# Altium schematic sheet 02-ece353_carrier.SchDoc
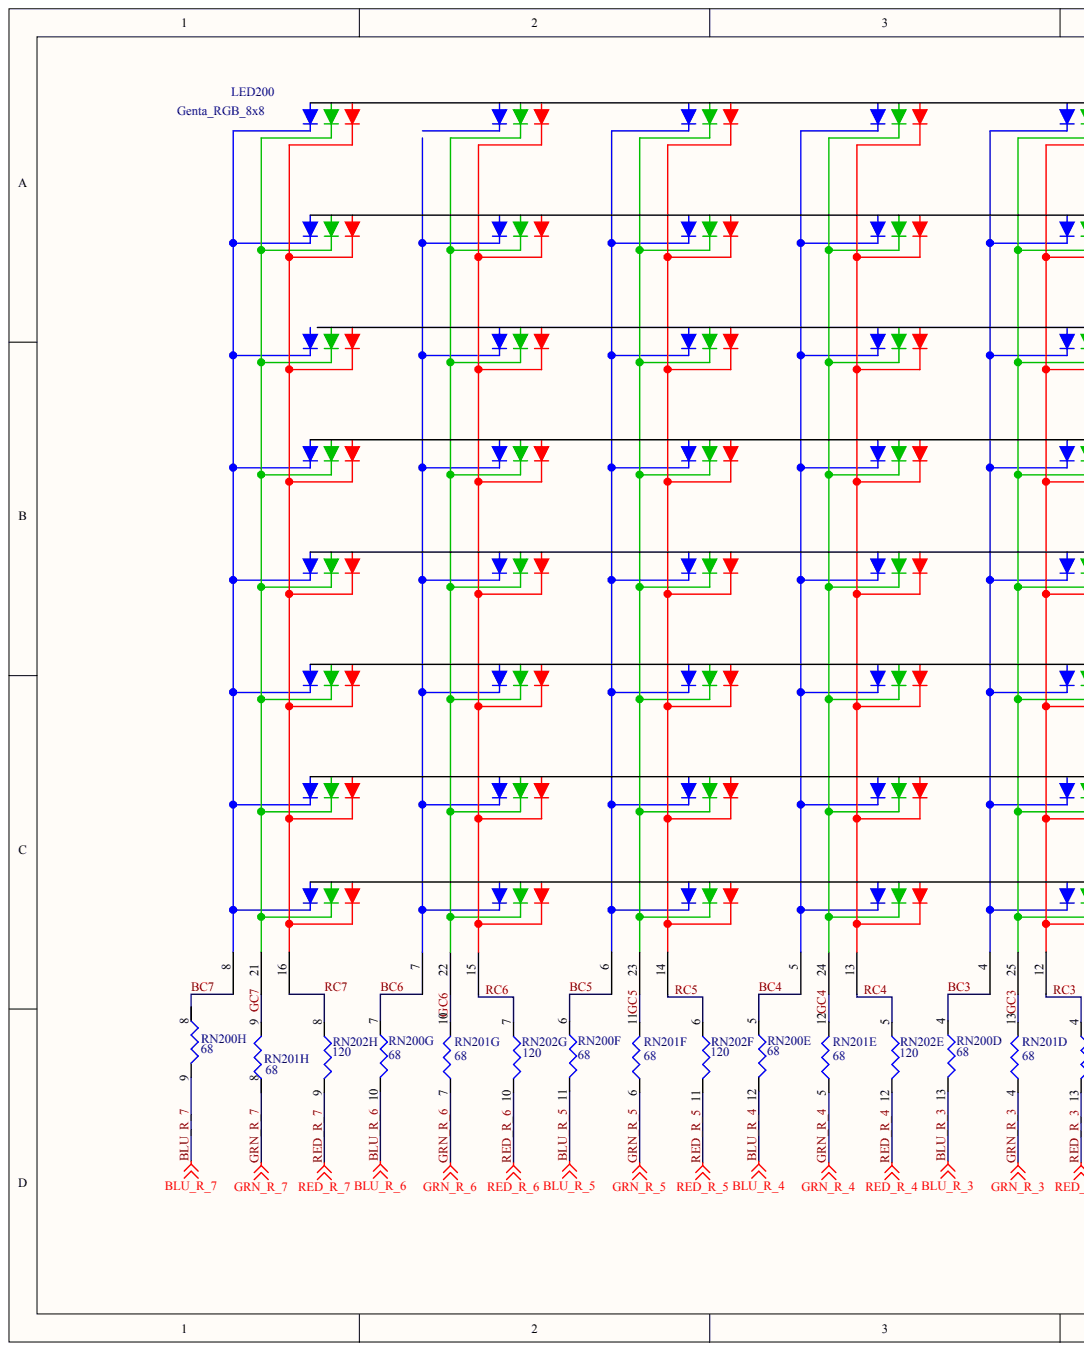
[diagram p0: 02-ece353_carrier.SchDoc, left]
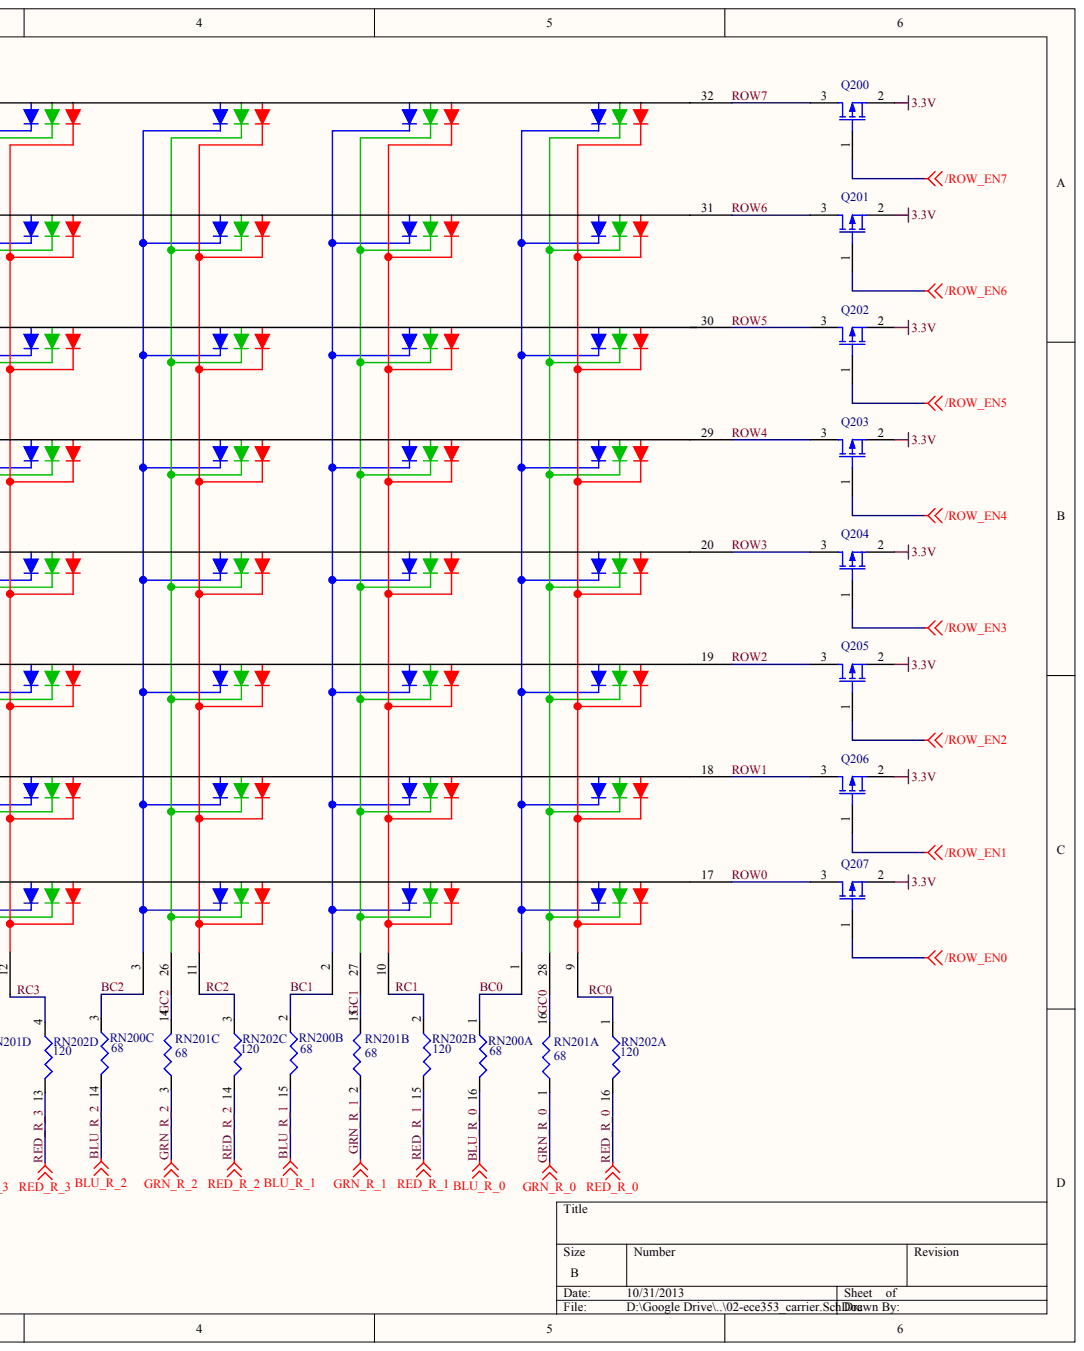
[diagram p1: 02-ece353_carrier.SchDoc, right]

## Parts
LED200  Genta_RGB_8x8
Q200  IRLML2244TRPBF  (value not drawn)
Q201  IRLML2244TRPBF  (value not drawn)
Q202  IRLML2244TRPBF  (value not drawn)
Q203  IRLML2244TRPBF  (value not drawn)
Q204  IRLML2244TRPBF  (value not drawn)
Q205  IRLML2244TRPBF  (value not drawn)
Q206  IRLML2244TRPBF  (value not drawn)
Q207  IRLML2244TRPBF  (value not drawn)
RN200  4816P-1-680LF  (value not drawn)  (parts A,B,C,D,E,F,G,H)
RN201  4816P-1-680LF  (value not drawn)  (parts A,B,C,D,E,F,G,H)
RN202  4816P-1-121LF  (value not drawn)  (parts A,B,C,D,E,F,G,H)

## Nets
3.3V: Q200.2 Q201.2 Q202.2 Q203.2 Q204.2 Q205.2 Q206.2 Q207.2
/ROW_EN0: Q207.1
/ROW_EN1: Q206.1
/ROW_EN2: Q205.1
/ROW_EN3: Q204.1
/ROW_EN4: Q203.1
/ROW_EN5: Q202.1
/ROW_EN6: Q201.1
/ROW_EN7: Q200.1
BC0: LED200.1 RN200.1
BC1: LED200.2 RN200.2
BC2: LED200.3 RN200.3
BC3: LED200.4 RN200.4
BC4: LED200.5 RN200.5
BC5: LED200.6 RN200.6
BC6: LED200.7 RN200.7
BC7: LED200.8 RN200.8
BLU_R_0: RN200.16
BLU_R_1: RN200.15
BLU_R_2: RN200.14
BLU_R_3: RN200.13
BLU_R_4: RN200.12
BLU_R_5: RN200.11
BLU_R_6: RN200.10
BLU_R_7: RN200.9
GC0: LED200.28 RN201.16
GC1: LED200.27 RN201.15
GC2: LED200.26 RN201.14
GC3: LED200.25 RN201.13
GC4: LED200.24 RN201.12
GC5: LED200.23 RN201.11
GC6: LED200.22 RN201.10
GC7: LED200.21 RN201.9
GRN_R_0: RN201.1
GRN_R_1: RN201.2
GRN_R_2: RN201.3
GRN_R_3: RN201.4
GRN_R_4: RN201.5
GRN_R_5: RN201.6
GRN_R_6: RN201.7
GRN_R_7: RN201.8
RC0: LED200.9 RN202.1
RC1: LED200.10 RN202.2
RC2: LED200.11 RN202.3
RC3: LED200.12 RN202.4
RC4: LED200.13 RN202.5
RC5: LED200.14 RN202.6
RC6: LED200.15 RN202.7
RC7: LED200.16 RN202.8
RED_R_0: RN202.16
RED_R_1: RN202.15
RED_R_2: RN202.14
RED_R_3: RN202.13
RED_R_4: RN202.12
RED_R_5: RN202.11
RED_R_6: RN202.10
RED_R_7: RN202.9
ROW0: LED200.17 Q207.3
ROW1: LED200.18 Q206.3
ROW2: LED200.19 Q205.3
ROW3: LED200.20 Q204.3
ROW4: LED200.29 Q203.3
ROW5: LED200.30 Q202.3
ROW6: LED200.31 Q201.3
ROW7: LED200.32 Q200.3

## Library data of the parts
RN200 RN201 = (no symbol name): Resistance: 68; Supplier 1: Digi-Key; Supplier Part Number 1: 4816P-1-680LFCT-ND
RN202 = (no symbol name): Resistance: 120; Supplier 1: Digi-Key; Supplier Part Number 1: 4816P-1-680LFCT-ND
LED200 Q200-Q207 = (no symbol name)
  Q200-Q207: Supplier 1: Newark; Supplier Part Number 1: 40T7448
